# Altium schematic sheet ocp_x8 - 副本.SchDoc
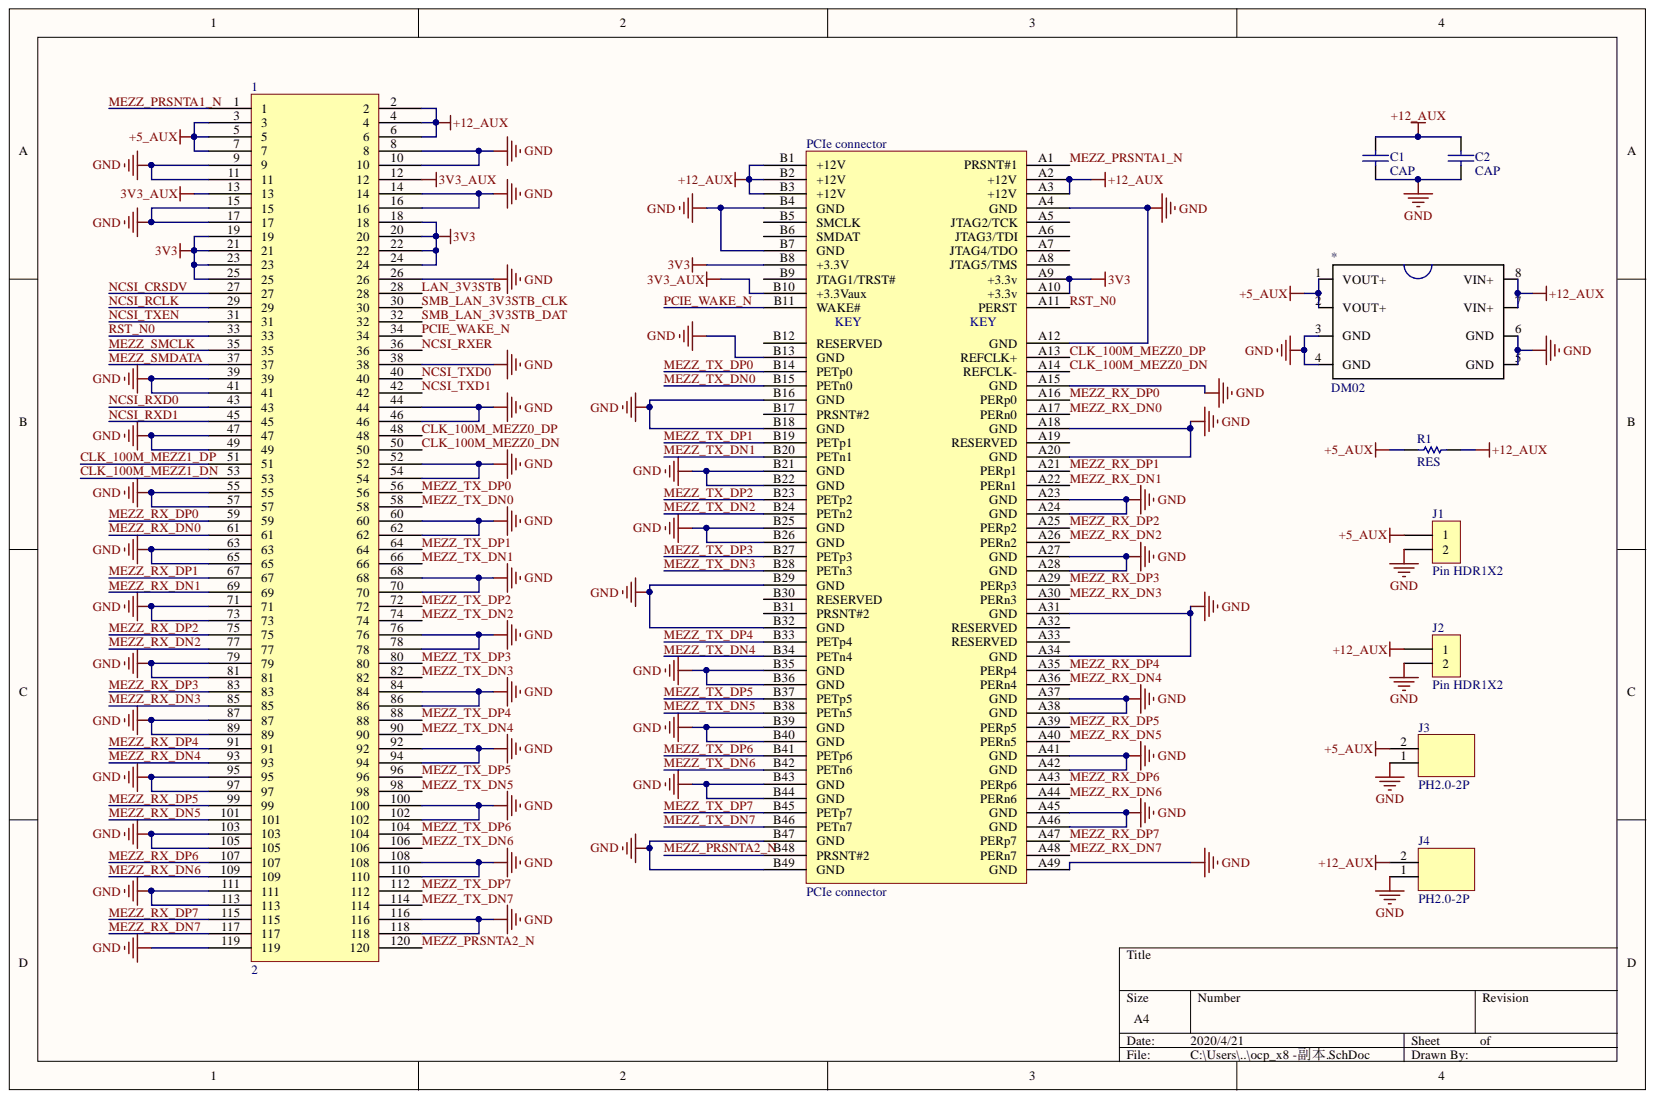
[diagram p0: ocp_x8 - 副本.SchDoc]

## Parts
1  2  [61082-122402]
*  DM02  [DM02]
C1  CAP  [0805C]
C2  CAP  [0805C]
J1  Pin HDR1X2  [Pin HDR1X2/2.54mm-S]
J2  Pin HDR1X2  [Pin HDR1X2/2.54mm-S]
J3  PH2.0-2P  [PH2.0W-2P]
J4  PH2.0-2P  [PH2.0W-2P]
PCIe_connector  PCIe connector  [PCIE_x8]
R1  RES  [1812R]

## Nets
3V3: 1.18 1.19 1.20 1.21 1.22 1.23 1.24 1.25 PCIe_connector.A9(+3.3v) PCIe_connector.A10(+3.3v) PCIe_connector.B8(+3.3V)
3V3_AUX: 1.12 1.13 PCIe_connector.B10(+3.3Vaux)
+5_AUX: 1.3 1.5 1.7 *.1(VOUT+) *.2(VOUT+) J1.1 J3.2 R1.1
+12_AUX: 1.2 1.4 1.6 *.7(VIN+) *.8(VIN+) C1.2 C2.2 J2.1 J4.2 PCIe_connector.A2(+12V) PCIe_connector.A3(+12V) PCIe_connector.B1(+12V) PCIe_connector.B2(+12V) PCIe_connector.B3(+12V) R1.2
GND: 1.8 1.9 1.10 1.11 1.14 1.15 1.16 1.17 1.26 1.38 1.39 1.41 1.44 1.46 1.47 1.49 1.52 1.54 1.55 1.57 1.60 1.62 1.63 1.65 1.68 1.70 1.71 1.73 1.76 1.78 1.79 1.81 1.84 1.86 1.87 1.89 1.92 1.94 1.95 1.97 1.100 1.102 1.103 1.105 1.108 1.110 1.111 1.113 1.116 1.118 1.119 *.3(GND) *.4(GND) *.5(GND) *.6(GND) C1.1 C2.1 J1.2 J2.2 J3.1 J4.1 PCIe_connector.A4(GND) PCIe_connector.A12(GND) PCIe_connector.A15(GND) PCIe_connector.A18(GND) PCIe_connector.A20(GND) PCIe_connector.A23(GND) PCIe_connector.A24(GND) PCIe_connector.A27(GND) PCIe_connector.A28(GND) PCIe_connector.A31(GND) PCIe_connector.A34(GND) PCIe_connector.A37(GND) PCIe_connector.A38(GND) PCIe_connector.A41(GND) PCIe_connector.A42(GND) PCIe_connector.A45(GND) PCIe_connector.A46(GND) PCIe_connector.A49(GND) PCIe_connector.B4(GND) PCIe_connector.B7(GND) PCIe_connector.B13(GND) PCIe_connector.B16(GND) PCIe_connector.B18(GND) PCIe_connector.B21(GND) PCIe_connector.B22(GND) PCIe_connector.B25(GND) PCIe_connector.B26(GND) PCIe_connector.B29(GND) PCIe_connector.B32(GND) PCIe_connector.B35(GND) PCIe_connector.B36(GND) PCIe_connector.B39(GND) PCIe_connector.B40(GND) PCIe_connector.B43(GND) PCIe_connector.B44(GND) PCIe_connector.B47(GND) PCIe_connector.B49(GND)
CLK_100M_MEZZ0_DN: 1.50 PCIe_connector.A14(REFCLK-)
CLK_100M_MEZZ0_DP: 1.48 PCIe_connector.A13(REFCLK+)
CLK_100M_MEZZ1_DN: 1.53
CLK_100M_MEZZ1_DP: 1.51
LAN_3V3STB: 1.28
MEZZ_PRSNTA1_N: 1.1 PCIe_connector.A1(PRSNT#1)
MEZZ_PRSNTA2_N: 1.120 PCIe_connector.B48(PRSNT#2)
MEZZ_RX_DN0: 1.61 PCIe_connector.A17(PERn0)
MEZZ_RX_DN1: 1.69 PCIe_connector.A22(PERn1)
MEZZ_RX_DN2: 1.77 PCIe_connector.A26(PERn2)
MEZZ_RX_DN3: 1.85 PCIe_connector.A30(PERn3)
MEZZ_RX_DN4: 1.93 PCIe_connector.A36(PERn4)
MEZZ_RX_DN5: 1.101 PCIe_connector.A40(PERn5)
MEZZ_RX_DN6: 1.109 PCIe_connector.A44(PERn6)
MEZZ_RX_DN7: 1.117 PCIe_connector.A48(PERn7)
MEZZ_RX_DP0: 1.59 PCIe_connector.A16(PERp0)
MEZZ_RX_DP1: 1.67 PCIe_connector.A21(PERp1)
MEZZ_RX_DP2: 1.75 PCIe_connector.A25(PERp2)
MEZZ_RX_DP3: 1.83 PCIe_connector.A29(PERp3)
MEZZ_RX_DP4: 1.91 PCIe_connector.A35(PERp4)
MEZZ_RX_DP5: 1.99 PCIe_connector.A39(PERp5)
MEZZ_RX_DP6: 1.107 PCIe_connector.A43(PERp6)
MEZZ_RX_DP7: 1.115 PCIe_connector.A47(PERp7)
MEZZ_SMCLK: 1.35
MEZZ_SMDATA: 1.37
MEZZ_TX_DN0: 1.58 PCIe_connector.B15(PETn0)
MEZZ_TX_DN1: 1.66 PCIe_connector.B20(PETn1)
MEZZ_TX_DN2: 1.74 PCIe_connector.B24(PETn2)
MEZZ_TX_DN3: 1.82 PCIe_connector.B28(PETn3)
MEZZ_TX_DN4: 1.90 PCIe_connector.B34(PETn4)
MEZZ_TX_DN5: 1.98 PCIe_connector.B38(PETn5)
MEZZ_TX_DN6: 1.106 PCIe_connector.B42(PETn6)
MEZZ_TX_DN7: 1.114 PCIe_connector.B46(PETn7)
MEZZ_TX_DP0: 1.56 PCIe_connector.B14(PETp0)
MEZZ_TX_DP1: 1.64 PCIe_connector.B19(PETp1)
MEZZ_TX_DP2: 1.72 PCIe_connector.B23(PETp2)
MEZZ_TX_DP3: 1.80 PCIe_connector.B27(PETp3)
MEZZ_TX_DP4: 1.88 PCIe_connector.B33(PETp4)
MEZZ_TX_DP5: 1.96 PCIe_connector.B37(PETp5)
MEZZ_TX_DP6: 1.104 PCIe_connector.B41(PETp6)
MEZZ_TX_DP7: 1.112 PCIe_connector.B45(PETp7)
NCSI_CRSDV: 1.27
NCSI_RCLK: 1.29
NCSI_RXD0: 1.43
NCSI_RXD1: 1.45
NCSI_RXER: 1.36
NCSI_TXD0: 1.40
NCSI_TXD1: 1.42
NCSI_TXEN: 1.31
PCIE_WAKE_N: 1.34 PCIe_connector.B11(WAKE#)
RST_N0: 1.33 PCIe_connector.A11(PERST)
SMB_LAN_3V3STB_CLK: 1.30
SMB_LAN_3V3STB_DAT: 1.32

Not connected: PCIe_connector A5(JTAG2/TCK) A6(JTAG3/TDI) A7(JTAG4/TDO) A8(JTAG5/TMS) A19(RESERVED) A32(RESERVED) A33(RESERVED) B5(SMCLK) B6(SMDAT) B9(JTAG1/TRST#) B12(RESERVED) B17(PRSNT#2) B30(RESERVED) B31(PRSNT#2)

## Library data of the parts
1 = 61082-122402: 120P连接器; Component Kind: Standard; Library Name: My_Library.IntLib
C1 C2 = CAP: 无极性电容; Component Kind: Standard; Library Name: 电容.IntLib
PCIe_connector = PCIe_X8: Component Kind: Standard; Library Name: My_Library.IntLib
* = DM02: Component Kind: Standard; Library Name: My_Library.IntLib
J1 J2 = Pin HDR1X2: Component Kind: Standard; Library Name: 排针.IntLib
J3 J4 = PH2.0-2P: Component Kind: Standard; Library Name: PH.IntLib
R1 = RES: Component Kind: Standard; Library Name: 电阻.IntLib
